# Altium schematic sheet Sheet1.SchDoc
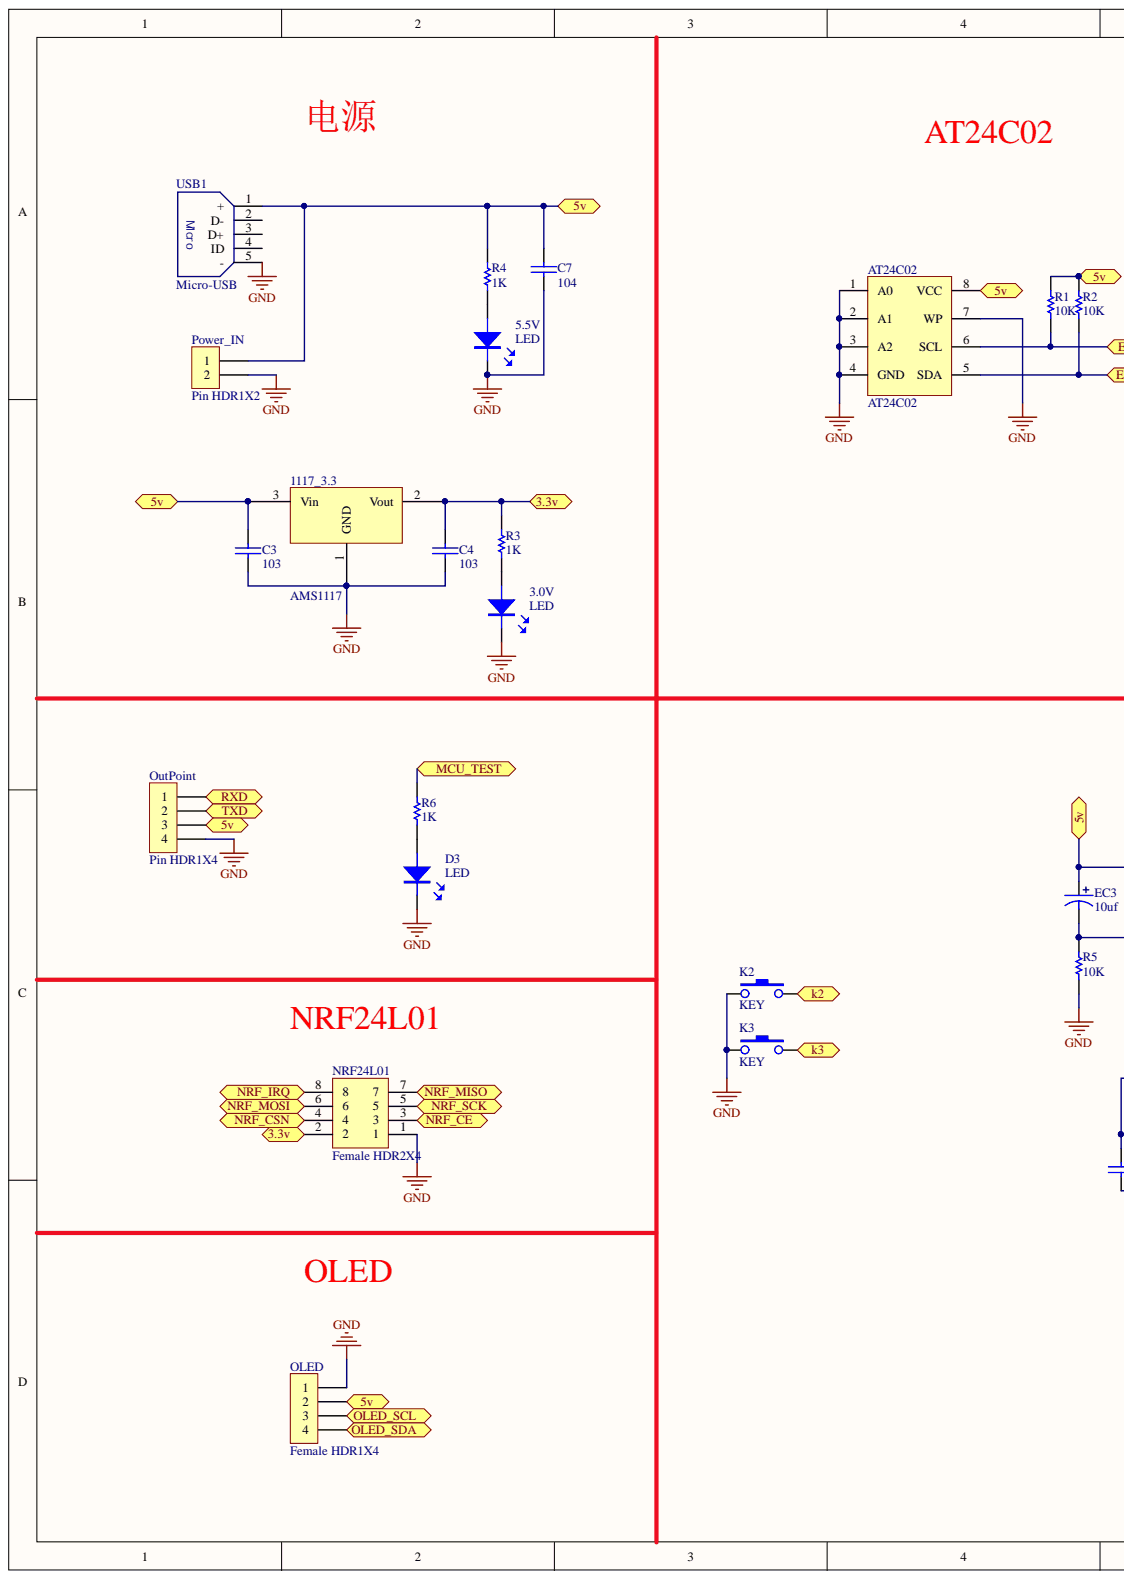
[diagram p0: Sheet1.SchDoc, left]
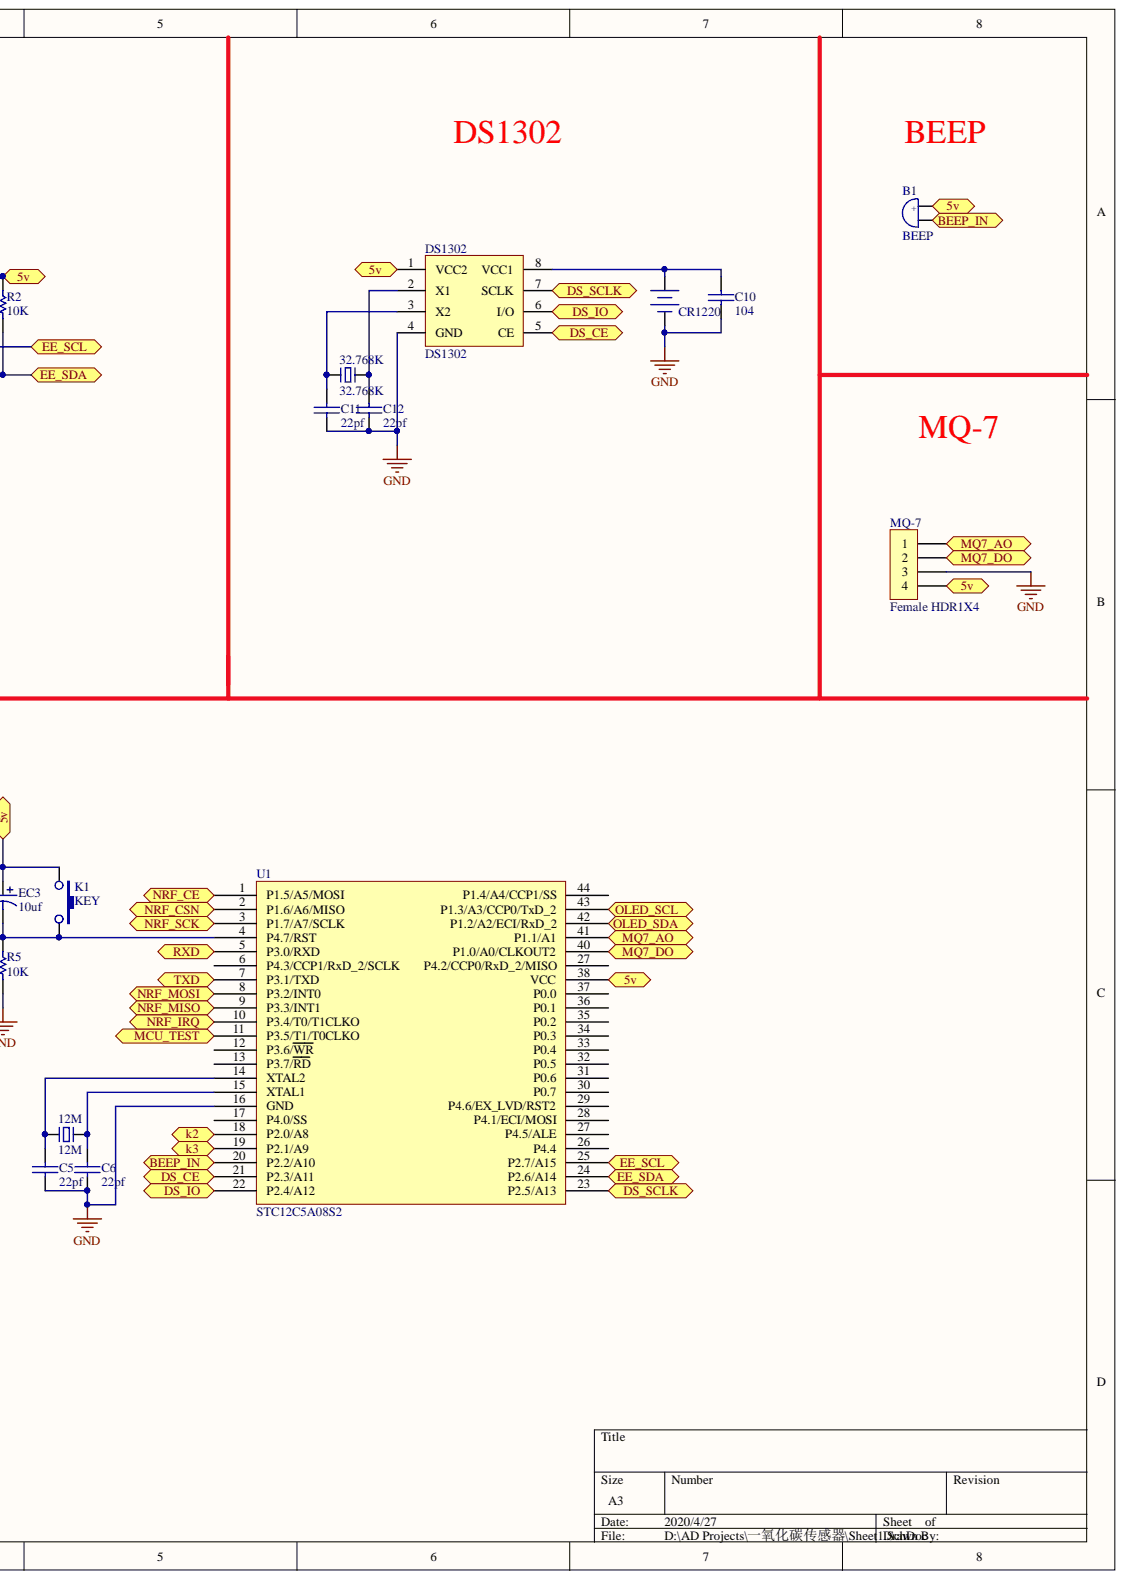
[diagram p1: Sheet1.SchDoc, right]

## Parts
3.0V  LED  [LED-0805B]
5.5V  LED  [LED-0805B]
12M  12M  [OSC HC-49S]
32.768K  32.768K  [OSC-3x10-WI-A]
1117_3.3  AMS1117  [SOT223]
AT24C02  AT24C02  [SOP8]
B1  BEEP  [BEEP 5x9x5.5]
BAT  CR1220  [CR1220-B]
C3  103  [0805C]
C4  103  [0805C]
C5  22pf  [0805C]
C6  22pf  [0805C]
C7  104  [0805C]
C10  104  [0805C]
C11  22pf  [0805C]
C12  22pf  [0805C]
D3  LED  [LED-0805B]
DS1302  DS1302  [SOP8]
EC3  10uf  [SMD-EC4.0X4.3X5.4]
K1  KEY  [SMD-3X6X2.5]
K2  KEY  [SMD-3X6X2.5]
K3  KEY  [SMD-3X6X2.5]
MQ-7  Female HDR1X4  [Fe-HDR1X4/2.54-S]
NRF24L01  Female HDR2X4  [Fe-HDR2X4/2.54-S]
OLED  Female HDR1X4  [Fe-HDR1X4/2.54-S]
OutPoint  Pin HDR1X4  [Pin HDR1X4/2.54mm-S]
Power_IN  Pin HDR1X2  [Pin HDR1X2/2.54mm-S]
R1  10K  [0805R]
R2  10K  [0805R]
R3  1K  [0805R]
R4  1K  [0805R]
R5  10K  [0805R]
R6  1K  [0805R]
U1  STC12C5A08S2  [LQFP44 10X10]
USB1  Micro-USB  [USB-MICRO_A]

## Ports
3.3V, 5V, BEEP_IN, DS_CE, DS_IO, DS_SCLK, EE_SCL, EE_SDA, K2, K3, MCU_TEST, MQ7_AO, MQ7_DO, NRF_CE, NRF_CSN, NRF_IRQ, NRF_MISO, NRF_MOSI, NRF_SCK, OLED_SCL, OLED_SDA, RXD, TXD

## Nets
GND: 3.0V.K 5.5V.K 1117_3.3.1 AT24C02.1(A0) AT24C02.2(A1) AT24C02.3(A2) AT24C02.4(GND) AT24C02.7(WP) BAT.2 C3.1 C4.1 C5.1 C6.1 C7.1 C10.1 C11.1 C12.1 D3.K DS1302.4(GND) K2.1 K3.1 MQ-7.3 NRF24L01.1 OLED.1 OutPoint.4 Power_IN.2 R5.1 U1.16(GND) USB1.5(-)
BEEP_IN: B1.2 U1.20(P2.2/A10) ; port BEEP_IN
DS_CE: DS1302.5(CE) U1.21(P2.3/A11) ; port DS_CE
DS_IO: DS1302.6(I/O) U1.22(P2.4/A12) ; port DS_IO
DS_SCLK: DS1302.7(SCLK) U1.23(P2.5/A13) ; port DS_SCLK
EE_SCL: AT24C02.6(SCL) R1.1 U1.25(P2.7/A15) ; port EE_SCL
EE_SDA: AT24C02.5(SDA) R2.1 U1.24(P2.6/A14) ; port EE_SDA
MCU_TEST: R6.2 U1.11(P3.5/T1/T0CLKO) ; port MCU_TEST
MQ7_AO: MQ-7.1 U1.41(P1.1/A1) ; port MQ7_AO
MQ7_DO: MQ-7.2 U1.40(P1.0/A0/CLKOUT2) ; port MQ7_DO
NRF_CE: NRF24L01.3 U1.1(P1.5/A5/MOSI) ; port NRF_CE
NRF_CSN: NRF24L01.4 U1.2(P1.6/A6/MISO) ; port NRF_CSN
NRF_IRQ: NRF24L01.8 U1.10(P3.4/T0/T1CLKO) ; port NRF_IRQ
NRF_MISO: NRF24L01.7 U1.9(P3.3/INT1) ; port NRF_MISO
NRF_MOSI: NRF24L01.6 U1.8(P3.2/INT0) ; port NRF_MOSI
NRF_SCK: NRF24L01.5 U1.3(P1.7/A7/SCLK) ; port NRF_SCK
OLED_SCL: OLED.3 U1.43(P1.3/A3/CCP0/TxD_2) ; port OLED_SCL
OLED_SDA: OLED.4 U1.42(P1.2/A2/ECI/RxD_2) ; port OLED_SDA
RXD: OutPoint.1 U1.5(P3.0/RXD) ; port RXD
TXD: OutPoint.2 U1.7(P3.1/TXD) ; port TXD
Net3.0V_A: 3.0V.A R3.1
Net5.5V_A: 5.5V.A R4.1
Net12M_1: 12M.1 C5.2 U1.14(XTAL2)
Net12M_2: 12M.2 C6.2 U1.15(XTAL1)
Net32.768K_1: 32.768K.1 C11.2 DS1302.3(X2)
Net32.768K_2: 32.768K.2 C12.2 DS1302.2(X1)
Net1117_3.3_2: 1117_3.3.2 C4.2 NRF24L01.2 R3.2 ; port 3.3V
Net1117_3.3_3: 1117_3.3.3 AT24C02.8(VCC) B1.1 C3.2 C7.2 DS1302.1(VCC2) EC3.1 K1.1 MQ-7.4 OLED.2 OutPoint.3 Power_IN.1 R1.2 R2.2 R4.2 U1.38(VCC) USB1.1(+) ; port 5V
NetBAT_1: BAT.1 C10.2 DS1302.8(VCC1)
NetD3_A: D3.A R6.1
NetEC3_2: EC3.2 K1.3 R5.2 U1.4(P4.7/RST)
NetK2_3: K2.3 U1.18(P2.0/A8) ; port K2
NetK3_3: K3.3 U1.19(P2.1/A9) ; port K3

Not connected: U1 6(P4.3/CCP1/RxD_2/SCLK) 12(P3.6/WR) 13(P3.7/RD) 17(P4.0/SS) 26(P4.4) 27(P4.2/CCP0/RxD_2/MISO) 28(P4.1/ECI/MOSI) 29(P4.6/EX_LVD/RST2) 30(P0.7) 31(P0.6) 32(P0.5) 33(P0.4) 34(P0.3) 35(P0.2) 36(P0.1) 37(P0.0) 44(P1.4/A4/CCP1/SS); USB1 2(D-) 3(D+) 4(ID)

## Library data of the parts
12M 32.768K = XTAL-2P: 2脚无源脚晶振; Component Kind: Standard; Library Name: 晶振.IntLib
1117_3.3 = AMS1117: 三端稳压芯片; Component Kind: Standard; Library Name: 电源芯片.IntLib
B1 = BEEP: 蜂鸣器; Component Kind: Standard; Library Name: 蜂鸣器.IntLib
C3-C7 C10-C12 = CAP: 无极性电容; Component Kind: Standard; Library Name: 电容.IntLib
EC3 = DIP_ECAP: 直插电解电容; Component Kind: Standard; Library Name: 电容.IntLib
R1-R6 = RES: Component Kind: Standard; Library Name: 电阻.IntLib
U1 = STC12C5AxxS2-44pin: STC单片机; 版权归属: 源创客; BOM名称: STC单片机; Component Kind: Standard; Library Name: STC系列单片机.SchLib; 学习资源: www.ooocke.com
3.0V 5.5V D3 = LED: Component Kind: Standard; Library Name: LED.IntLib
AT24C02 = AT24C02: Component Kind: Standard; Library Name: 未分类IC.IntLib
BAT = CR1220: Component Kind: Standard; Library Name: 纽扣电池座.IntLib
DS1302 = DS1302: Component Kind: Standard; Library Name: 通讯系列IC.IntLib
K1-K3 = KEY: Component Kind: Standard; Library Name: 轻触开关.IntLib
MQ-7 OLED = Female HDR1X4: Component Kind: Standard; Library Name: 排母.IntLib
NRF24L01 = Female HDR2X4: Component Kind: Standard; Library Name: 排母.IntLib
OutPoint = Pin HDR1X4: Component Kind: Standard; Library Name: 排针.IntLib
Power_IN = Pin HDR1X2: Component Kind: Standard; Library Name: 排针.IntLib
USB1 = Micro-USB: Component Kind: Standard; Library Name: USB.IntLib
